# Altium schematic sheet Hope Badge.SchDoc
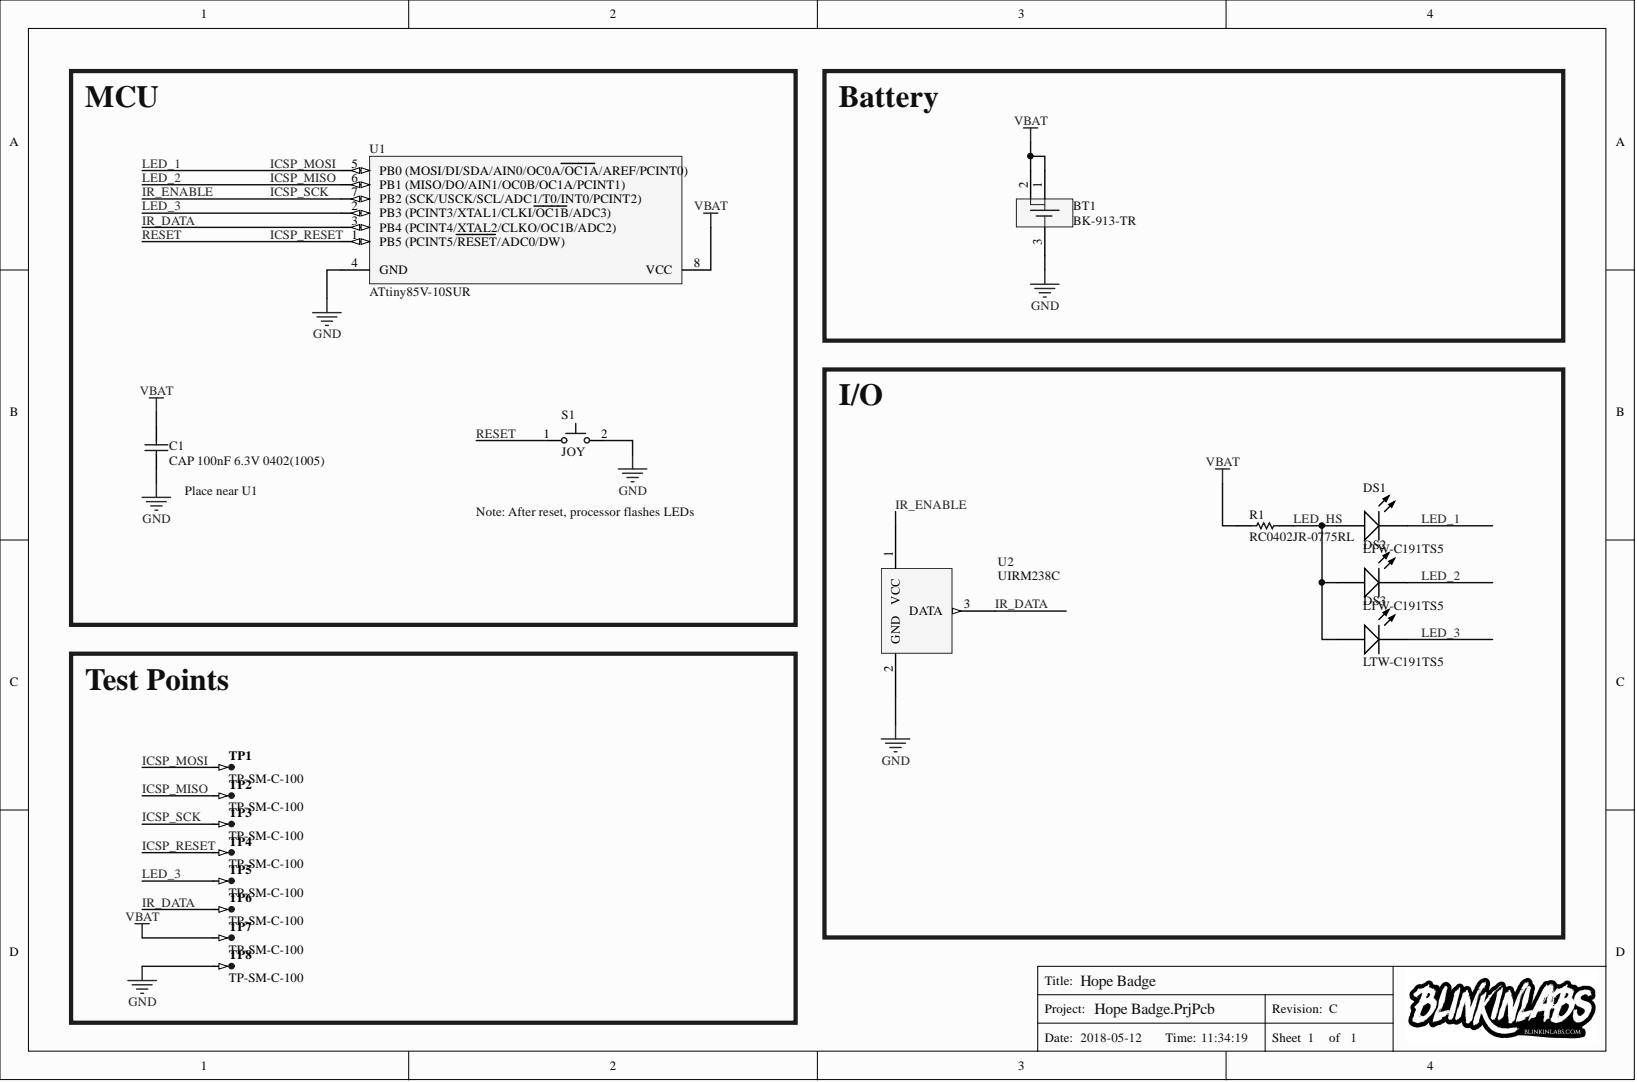
[diagram p0: Hope Badge.SchDoc]

## Parts
BT1  BK-913-TR  [MPD-BK-913-3_V]
C1  CAP 100nF 6.3V 0402(1005)  value 100nF  [CAPC0402(1005)60_N]
DS1  LTW-C191TS5  [LTST-LTW-C191TS5_V]
DS2  LTW-C191TS5  [LTST-LTW-C191TS5_V]
DS3  LTW-C191TS5  [LTST-LTW-C191TS5_V]
R1  RC0402JR-0775RL  [RESC1005X40X25ML5T10]
S1  JOY  [TS-1185]
TP1  TP-SM-C-100  [TP-SM-C-100]  (no BOM)
TP2  TP-SM-C-100  [TP-SM-C-100]  (no BOM)
TP3  TP-SM-C-100  [TP-SM-C-100]  (no BOM)
TP4  TP-SM-C-100  [TP-SM-C-100]  (no BOM)
TP5  TP-SM-C-100  [TP-SM-C-100]  (no BOM)
TP6  TP-SM-C-100  [TP-SM-C-100]  (no BOM)
TP7  TP-SM-C-100  [TP-SM-C-100]  (no BOM)
TP8  TP-SM-C-100  [TP-SM-C-100]  (no BOM)
U1  ATtiny85V-10SUR  [8S2_M]
U2  UIRM238C  [UIRM238C]

## Nets
GND: BT1.3 C1.1 S1.2 TP8.1 U1.4(GND) U2.2
VBAT: BT1.1 BT1.2 C1.2 R1.2 TP7.1 U1.8(VCC)
ICSP_MISO: DS2.2 TP2.1 U1.6(PB1_(MISO/DO/AIN1/OC0B/OC1A/PCINT1))
ICSP_MOSI: DS1.2 TP1.1 U1.5(PB0_(MOSI/DI/SDA/AIN0/OC0A/OC1A/AREF/PCINT0))
ICSP_RESET: S1.1 TP4.1 U1.1(PB5_(PCINT5/RESET/ADC0/DW))
ICSP_SCK: TP3.1 U1.7(PB2_(SCK/USCK/SCL/ADC1/T0/INT0/PCINT2)) U2.1
IR_DATA: TP6.1 U1.3(PB4_(PCINT4/XTAL2/CLKO/OC1B/ADC2)) U2.3
LED_3: DS3.2 TP5.1 U1.2(PB3_(PCINT3/XTAL1/CLKI/OC1B/ADC3))
LED_HS: DS1.1 DS2.1 DS3.1 R1.1

## Library data of the parts
BT1 = CMP-0460-00001-1: Battery Retainer for 20 mm Dia. Batteries, RoHS, Bag; Manufacturer: Memory Protection Devices; Battery Series: 2032; Battery Type, Function: Coin Cell, Retainer; Number of Cells: 1; Category: Battery Products; Component Kind: Standard; ComponentLink1Description: Manufacturer URL; ComponentLink1URL: http://www.memoryprotectiondevices.com/; ComponentLink2Description: Datasheet; ComponentLink2URL: http://www.memoryprotectiondevices.com/datasheets/BK-913-datasheet.pdf; ComponentLink3Description: Package Specification; ComponentLink3URL: http://www.memoryprotectiondevices.com/datasheets/BK-913-datasheet.pdf; DatasheetVersion: May-10; Family: Battery Holders, Clips, Contacts; Library Name: Altium Content Vault; Mounting Technology: Through Hole; PackageDescription: 3-Pin Through Hole Retainer, Body 21.08 x 15.24 mm, Height 4.27 mm; PackageReference: BK-913-3; PackageVersion: May-10; Packing: Bag; PartNumber: BK-913-TR; RoHS: TRUE
C1 = CMP-1034-02063-1: CAP 100nF 6.3V -20% to +80% 0402 (1005 Metric) Thickness 0.6mm SMD; Case-EIA: 0402; Case-Metric: 1005; Component Kind: Standard; Library Name: Altium Content Vault; Max Thickness: 0.6 mm; Rated Voltage: 6.3 V; Technology: SMT; Tolerance: -20% to +80%
DS1-DS3 = CMP-1674-00013-1: LED Uni-Color White, 70 mW, 20 mA, -20 to 80 degC, 2-Pin SMD, RoHS, Tape and Reel; Case\Package: 0603; Power Rating: 100 mW; Resistance: 12 Ohm; Tolerance: 1%; Voltage Rating: 50 V; Case Code (Imperial): 0603; Case Code (Metric): 1608; Component Kind: Standard; ComponentLink1Description: Manufacturer URL; ComponentLink1URL: http://www.us.liteon.com/; ComponentLink2Description: Datasheet; ComponentLink2URL: http://optoelectronics.liteon.com/upload/download/DS22-2004-201/S_110_LTW-C191TS5.pdf; DatasheetVersion: Rev. B, 06/2010; Height: 0.45 mm; Length: 1.6 mm; Library Name: Altium Content Vault; Max Operating Temperature: 155 degC; Min Operating Temperature: -55 degC; Mount: Surface Mount; PackageDescription: 2-Pin Surface Mount Device, Body 1.6 x 0.8 mm; Package Quantity: 5000; PackageReference: LTW-C191TS5; Packaging: Tape and Reel; Pins: 2; REACH SVHC: No SVHC; RoHSCompliant: true; Voltage Rating (DC): 50 V; Width: 0.8 mm
R1 = CMP-2002-08044-2: Chip Resistor, 75 Ohm, +/- 5%, 0.063 W, -55 to 155 degC, 0402 (1005 Metric), RoHS, Tape and Reel; Power Rating: 62.5 mW; Resistance: 75 Ohm; Voltage Rating: 50 V; Case/Package: 0402; Case Code (Imperial): 0402; Case Code (Metric): 1005; Component Kind: Standard; ComponentLink1Description: Manufacturer URL; ComponentLink1URL: http://www.yageo.com/; ComponentLink2Description: Datasheet; ComponentLink2URL: http://www.yageo.com/documents/recent/PYu-RC_Group_51_RoHS_L_04.pdf; DatasheetVersion: Rev. 4, 02/2016; Height: 0.35 mm; Length: 1 mm; Library Name: Altium Content Vault; Max Operating Temperature: 155 degC; Min Operating Temperature: -55 degC; Mount: Surface Mount; Mounting Technology: Surface Mount; PackageDescription: 2-Pin Surface Mount Device, Body 1 x 0.5 mm; Package Quantity: 200; Packaging: Tape and Reel; PartNumber: RC0402JR-0775RL; Radiation Hardening: No; REACH SVHC: No SVHC; RoHSCompliant: true; Tolerance: 5%; Width: 0.5 mm
S1 = TS-1185: Tact Switch, 3x4mm, no alignment pin, turtle style; Component Kind: Standard; Library Name: Blinkinlabs.IntLib
TP1-TP8 = CMP-0484-00002-2: Test Point, Surface Mount, Circular, Pad Diameter 1 mm; Manufacturer: No Vendor; Library Name: Altium Content Vault; PartNumber: TP-SM-C-100
U1 = CMP-0093-00335-1: 8-bit AVR Microcontroller, 10MHz, 1.8-5.5V, 8K Flash, 512 Bytes EEPROM, 512 Bytes SRAM, Industrial Grade (-40°C to 85°C), 8-Lead SOIC, Pb-Free, Reel; Component Kind: Standard; ComponentLink1Description: Manufacturer URL; ComponentLink1URL: http://www.atmel.com/; ComponentLink2Description: ATtiny25/45/85 Datasheet; ComponentLink2URL: http://www.atmel.com/dyn/resources/prod_documents/doc2586.pdf; ComponentLink3Description: ATtiny25/45/85 Summary; ComponentLink3URL: http://www.atmel.com/dyn/resources/prod_documents/2586S.pdf; DatasheetVersion: revN, Apr-2011; Library Name: Altium Content Vault; PackageReference: 8S2; PackageVersion: revF, Apr-2008
U2 = UIRM238C: Infrared Receiver Module; Component Kind: Standard; Library Name: Blinkinlabs.IntLib
